# Altium schematic sheet Power.SchDoc(Power)
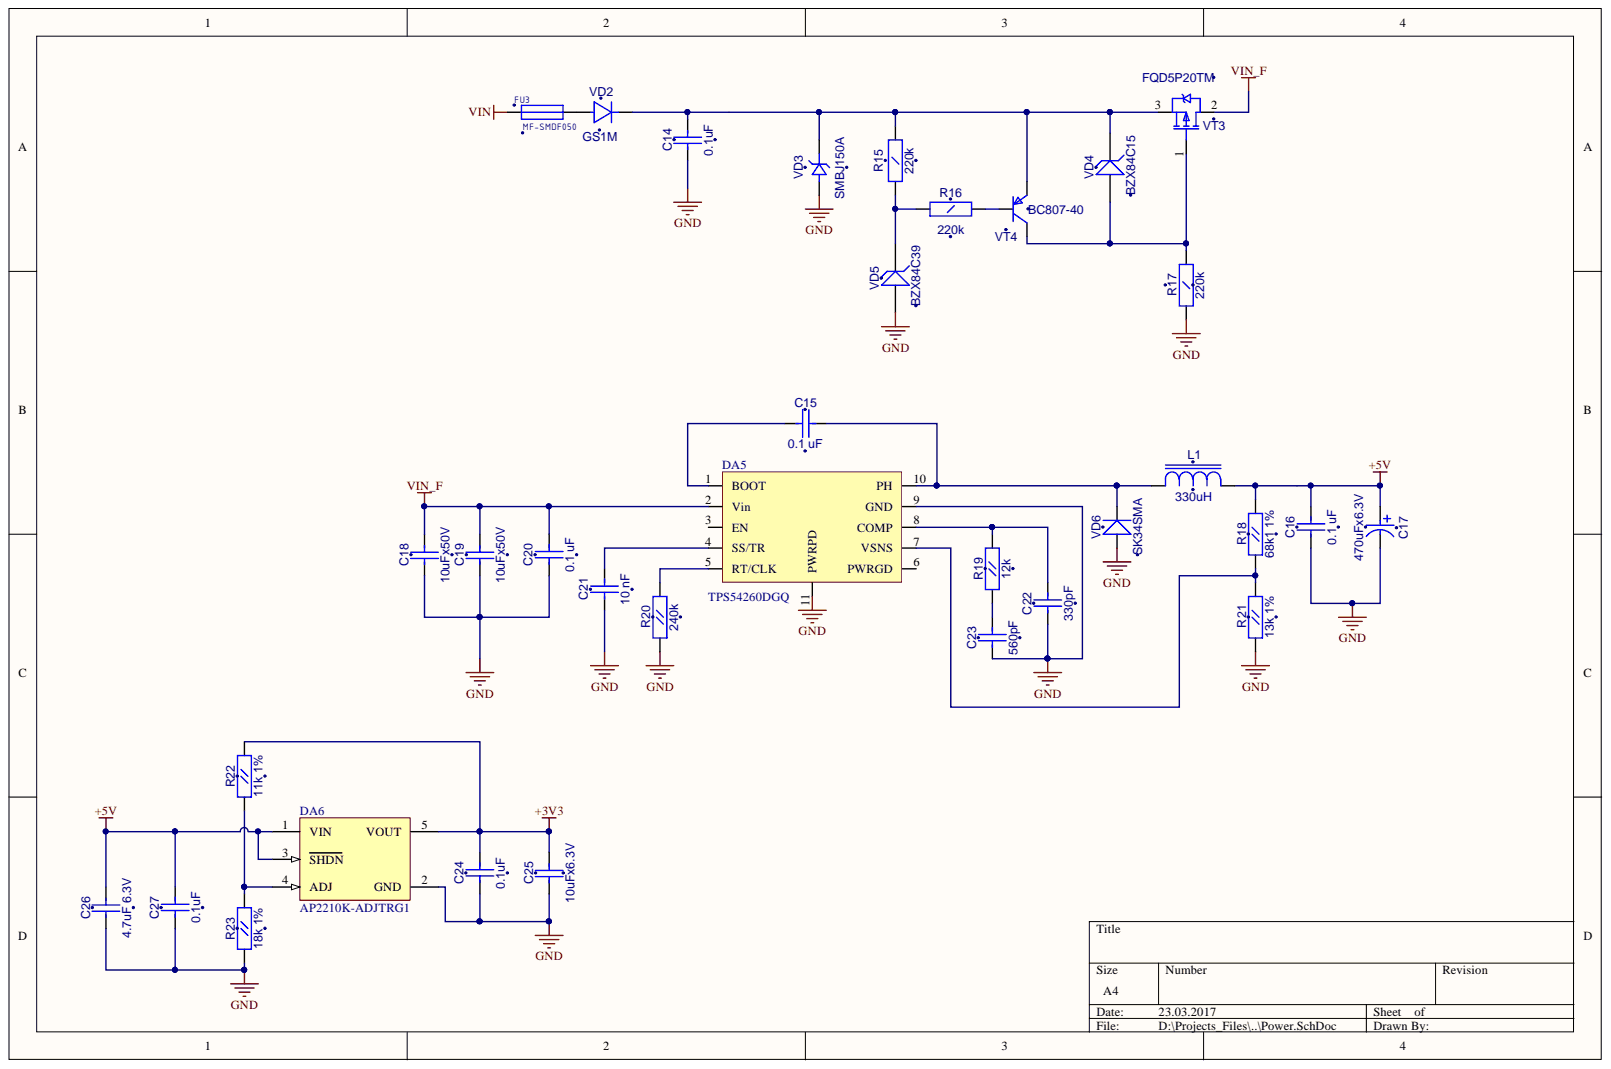
[diagram p0: Power.SchDoc]

## Parts
C14  0.1uF  [CC1206]
C15  0.1 uF  [CC0603]
C16  0.1 uF  [CC0603]
C17  470uFx6.3V  [TAJ-D-1M]
C18  10uFx50V  [CC1210]
C19  10uFx50V  [CC1210]
C20  0.1 uF  [CC0603]
C21  10 nF  [CC0603]
C22  330pF  [CC0603]
C23  560pF  [CC0603]
C24  0.1uF  [CC0603]
C25  10uFx6.3V  [CC0805]
C26  4.7uF 6.3V  [CC0805]
C27  0.1uF  [CC0603]
DA5  TPS54260DGQ  [S-PDSO-G10]
DA6  AP2210K-ADJTRG1  [SOT23-5]
FU3  MF-SMDF050  [MF-SMDF]
L1  330uH  [SDR0603]
R15  220k  [RC1206]
R16  220k  [RC1206]
R17  220k  [RC1206]
R18  68k1 1%  [RC0603]
R19  12k  [RC0603]
R20  240k  [RC0603]
R21  13k 1%  [RC0603]
R22  11k 1%  [RC0603]
R23  18k 1%  [RC0603]
VD2  GS1M  [SMA]
VD3  SMBJ150A  [SMB]
VD4  BZX84C15  [SOT-23(123)]
VD5  BZX84C39  [SOT-23(123)]
VD6  SK34SMA  [SMA]
VT3  FQD5P20TM  [D-PAK(TO-252AA)]
VT4  BC807-40  [SOT-23(123)]

## Nets
+3V3: C24.1 C25.2 DA6.5(VOUT) R22.2
+5V: C16.1 C17.1 C26.2 C27.1 DA6.1(VIN) DA6.3(SHDN) L1.2 R18.2
GND: C14.1 C16.2 C17.2 C18.1 C19.1 C20.2 C21.2 C22.2 C23.2 C24.2 C25.1 C26.1 C27.2 DA5.9(GND) DA5.11(PWRPD) DA6.2(GND) R17.2 R20.1 R21.1 R23.1 VD3.1 VD5.1 VD6.1
VIN: FU3.1
VIN_F: C18.2 C19.2 C20.1 DA5.2(Vin) VT3.2
NetC14_2: C14.2 R15.2 VD2.2 VD3.2 VD4.3 VT3.3 VT4.2
NetC15_1: C15.1 DA5.10(PH) L1.1 VD6.2
NetC15_2: C15.2 DA5.1(BOOT)
NetC21_1: C21.1 DA5.4(SS/TR)
NetC22_1: C22.1 DA5.8(COMP) R19.2
NetC23_1: C23.1 R19.1
NetDA5_5: DA5.5(RT/CLK) R20.2
NetDA5_7: DA5.7(VSNS) R18.1 R21.2
NetDA6_4: DA6.4(ADJ) R22.1 R23.2
NetFU3_2: FU3.2 VD2.1
NetR15_1: R15.1 R16.1 VD5.3
NetR16_2: R16.2 VT4.1
NetR17_1: R17.1 VD4.1 VT3.1 VT4.3

Not connected: DA5 3(EN) 6(PWRGD)

## Library data of the parts
C14 = CC1206: Component Kind: Standard; Description: CC1206; Value: 0.1uF; Library Name: gprs_modem_2.SchLib
C15 C16 C20-C24 C27 = CC0603: Component Kind: Standard; Description: CC0603; Library Name: gprs_modem_2.SchLib
  C15 C16 C20: Value: 0.1 uF
  C21: Value: 10 nF
  C22: Value: 330pF
  C23: Value: 560pF
  C24 C27: Value: 0.1uF
C17 = TAJ-D: Component Kind: Standard; Description: TAJ-D; Value: 470uFx6.3V; Library Name: gprs_modem_2.SchLib
C18 C19 = CC1210: Component Kind: Standard; Description: CC1210; Value: 10uFx50V; Library Name: gprs_modem_2.SchLib
C25 C26 = CC0805: Component Kind: Standard; Description: CC0805; Library Name: gprs_modem_2.SchLib
  C25: Value: 10uFx6.3V
  C26: Value: 4.7uF 6.3V
DA6 = MCP1824T-ADJE/OT: 300 mA, Low Voltage, Low Quiescent Current LDO Regulator, 5-Pin SOT-223, Extended Temperature, Tape and Reel; Component Kind: Standard; ComponentLink1Description: Manufacturer Link; ComponentLink1URL: http://www.microchip.com; ComponentLink2Description: Datasheet; ComponentLink2URL: http://ww1.microchip.com/downloads/en/DeviceDoc/22070a.pdf; ComponentLink3Description: Packaging Specification; ComponentLink3URL: http://ww1.microchip.com/downloads/en/PackagingSpec/00049AW.pdf; DatasheetVersion: revA, Aug-2007; PackageDescription: 5-Lead Plastic Small Outline Transistor (DC) [SOT-223]; PackageReference: SOT-223-DC5; PackageVersion: revAW, Oct-2008; Published: 29-Jan-2009; Library Name: gprs_modem_2.SchLib; Publisher: Altium Limited
FU3 = MF-SMDF: Component Kind: Standard; Description: MF-SMDF; Value: MF-SMDF050; Library Name: gprs_modem_2.SchLib
L1 = SDR0603: Component Kind: Standard; Description: SDR0603; Value: 330uH; Library Name: gprs_modem_2.SchLib
R15-R17 = RC1206: Component Kind: Standard; Description: RC1206; Value: 220k; Library Name: gprs_modem_2.SchLib
R18-R23 = RC0603: Component Kind: Standard; Description: RC0603; Library Name: gprs_modem_2.SchLib
  R18: Value: 68k1 1%
  R19: Value: 12k
  R20: Value: 240k
  R21: Value: 13k 1%
  R22: Value: 11k 1%
  R23: Value: 18k 1%
VD2 = GS1M: Value: {Value}; Component Kind: Standard; Description: GS1M; Library Name: gprs_modem.SchLib
VD3 = SMBJ: Component Kind: Standard; Description: SMBJ; Value: SMBJ150A; Library Name: gprs_modem_2.SchLib
VD4 VD5 = BZX84: Zener Voltage Regulator Diode; Value: {Value}; Component Kind: Standard; Library Name: gprs_modem_2.SchLib
VD6 = SK34SMA: Schottky Rectifier; Value: {Value}; Component Kind: Standard; Library Name: gprs_modem.SchLib
VT3 = IRFR9220: HEXFET Power MOSFET; ComponentField7: Published 8-Jun-2000; OriginalName: IRLML5103; Component Kind: Standard; Value: FQD5P20TM; Library Name: gprs_modem_2.SchLib
VT4 = BC857B: ComponentField7: Published 29-Sep-2000; OriginalName: BC846BLT1; Component Kind: Standard; Description: BC857B; Simulation: BC846BLT1; Value: BC807-40; Library Name: gprs_modem.SchLib
DA5 = TPS54260DGQ: Component Kind: Standard; Library Name: gprs_modem.SchLib
